# Altium schematic sheet 03_MCU-0220_S32K324.SchDoc
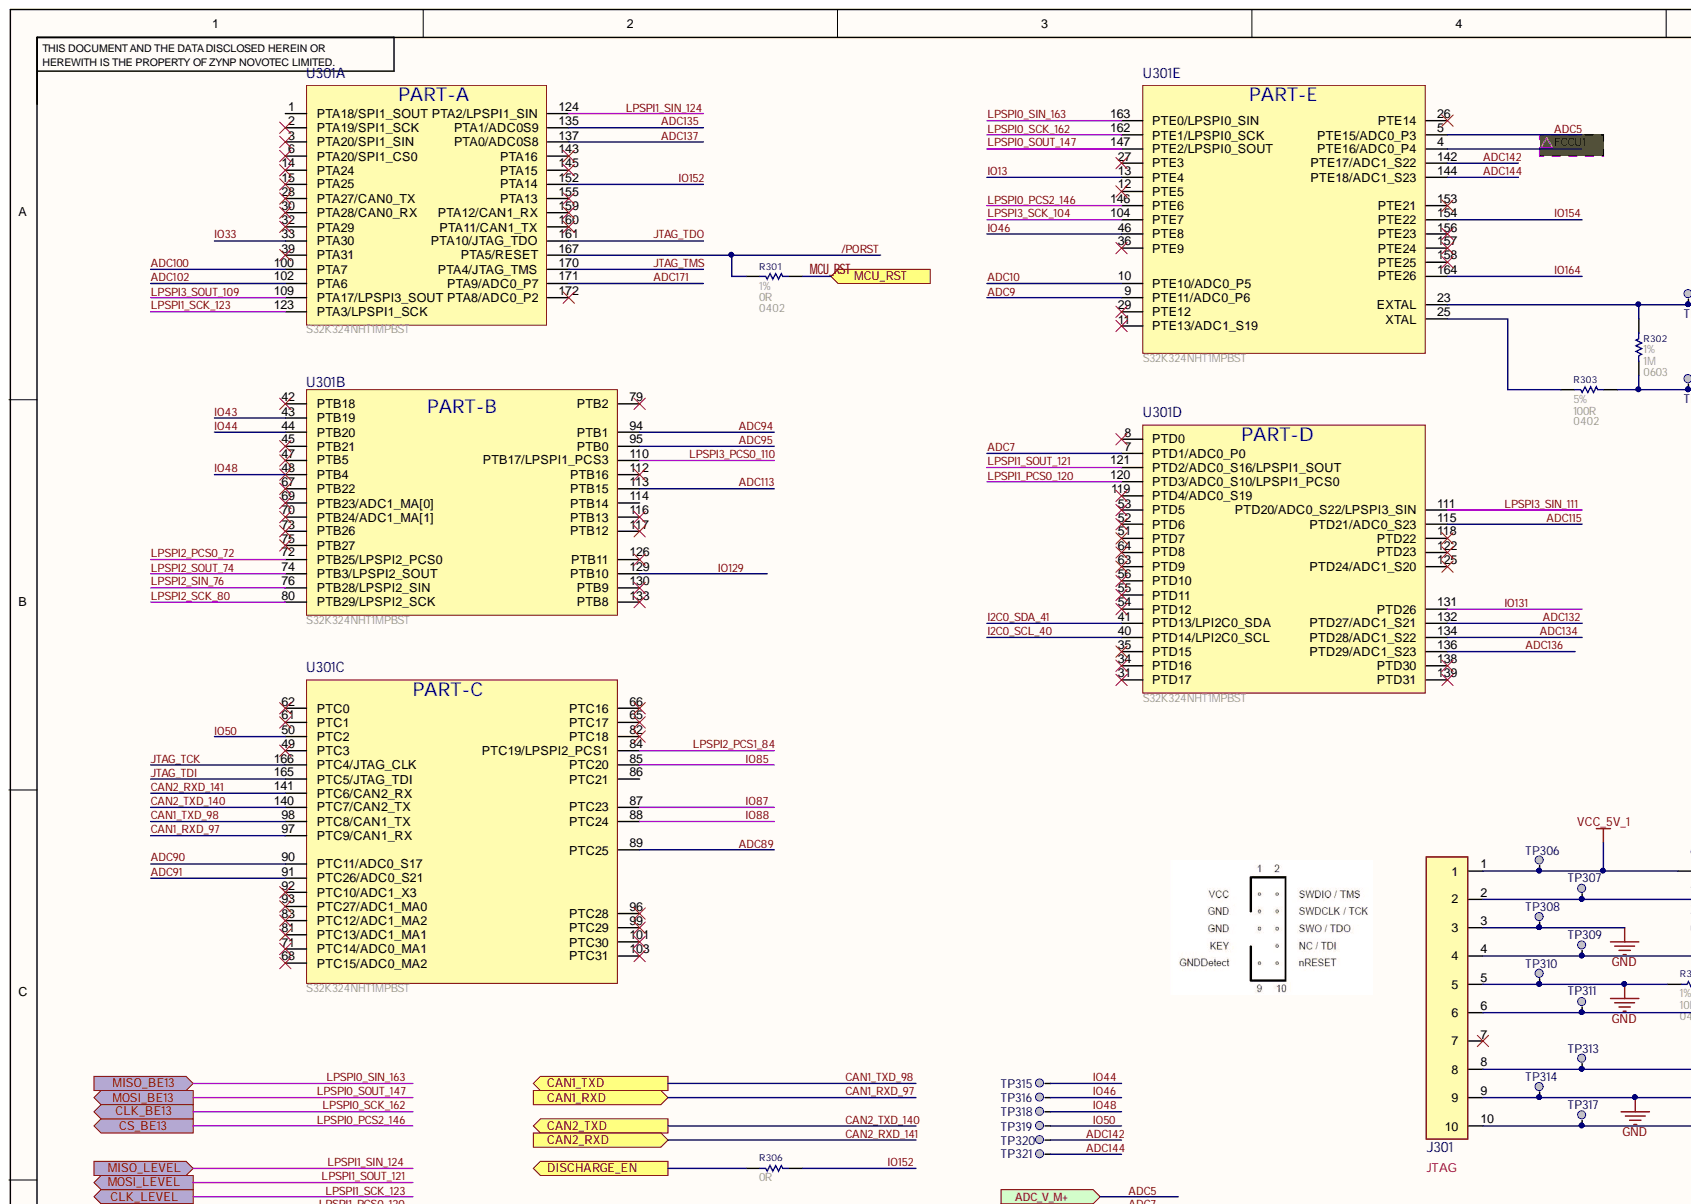
[diagram p0: 03_MCU-0220_S32K324.SchDoc, top left]
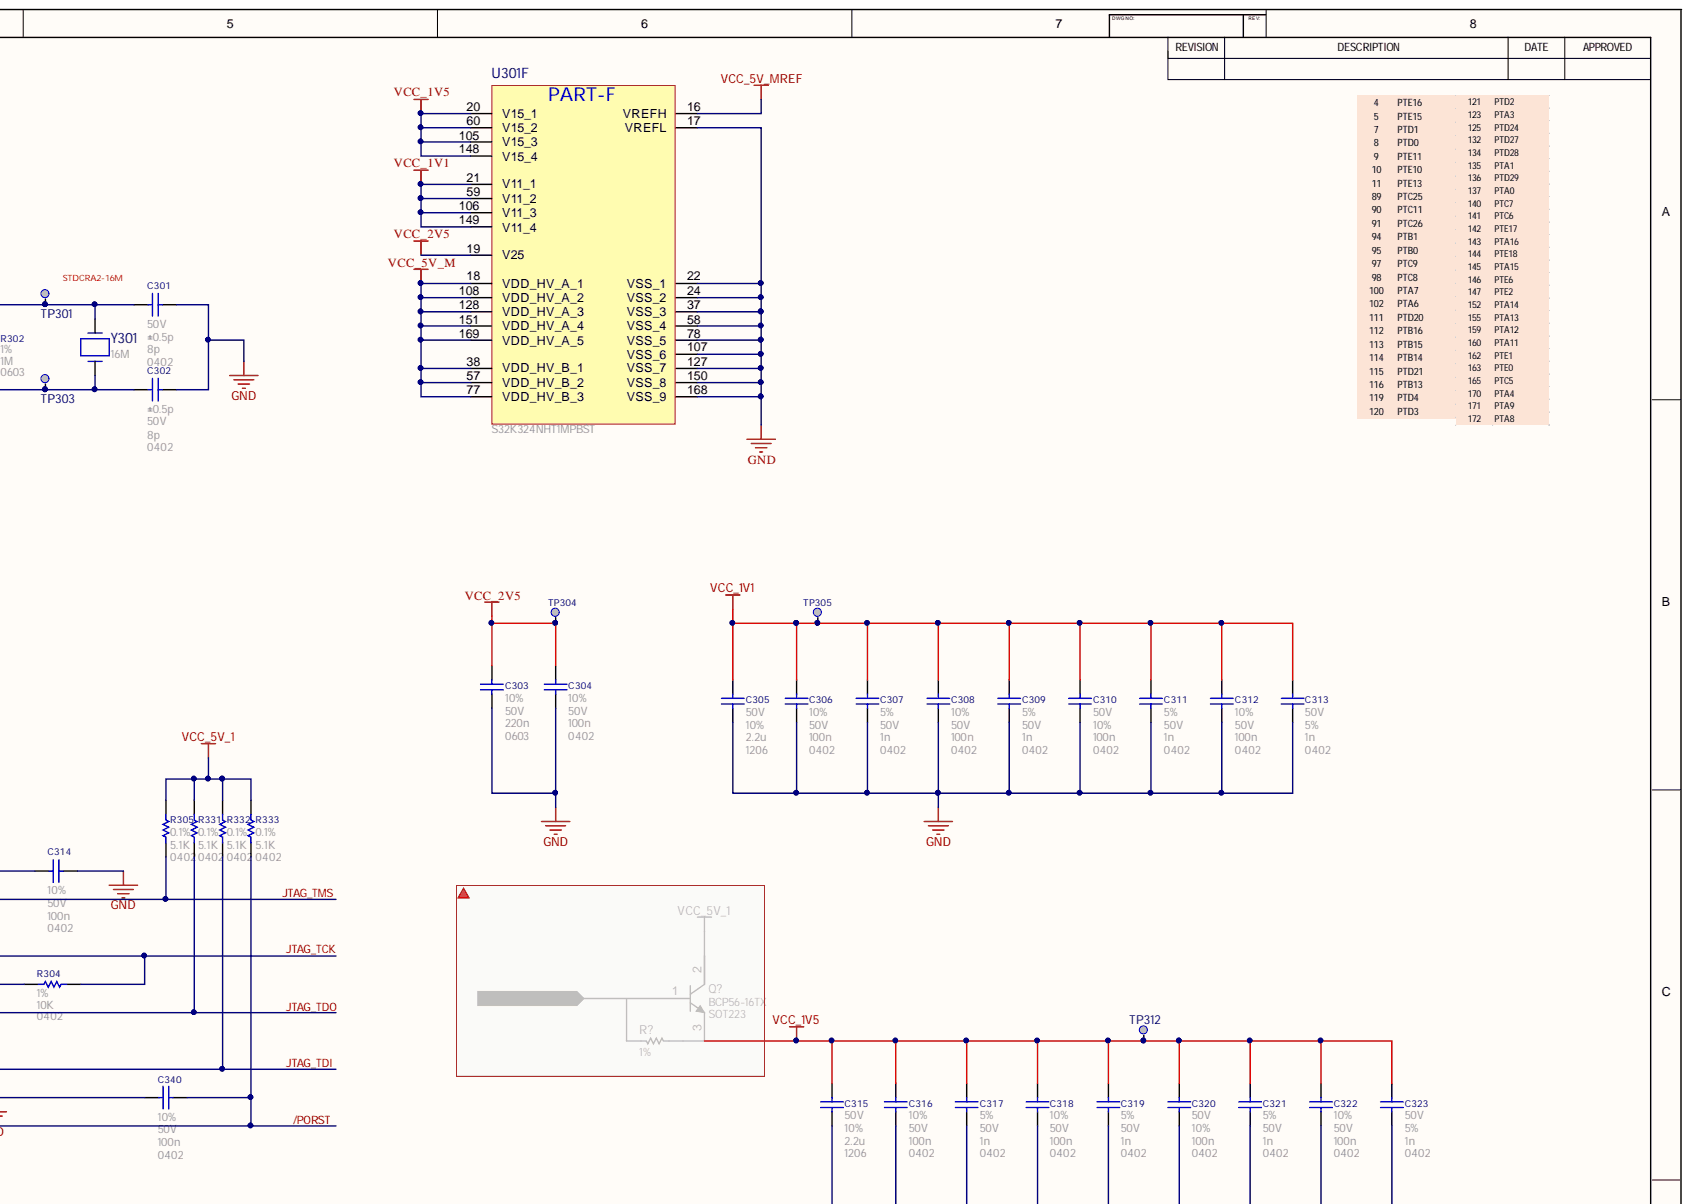
[diagram p1: 03_MCU-0220_S32K324.SchDoc, top right]
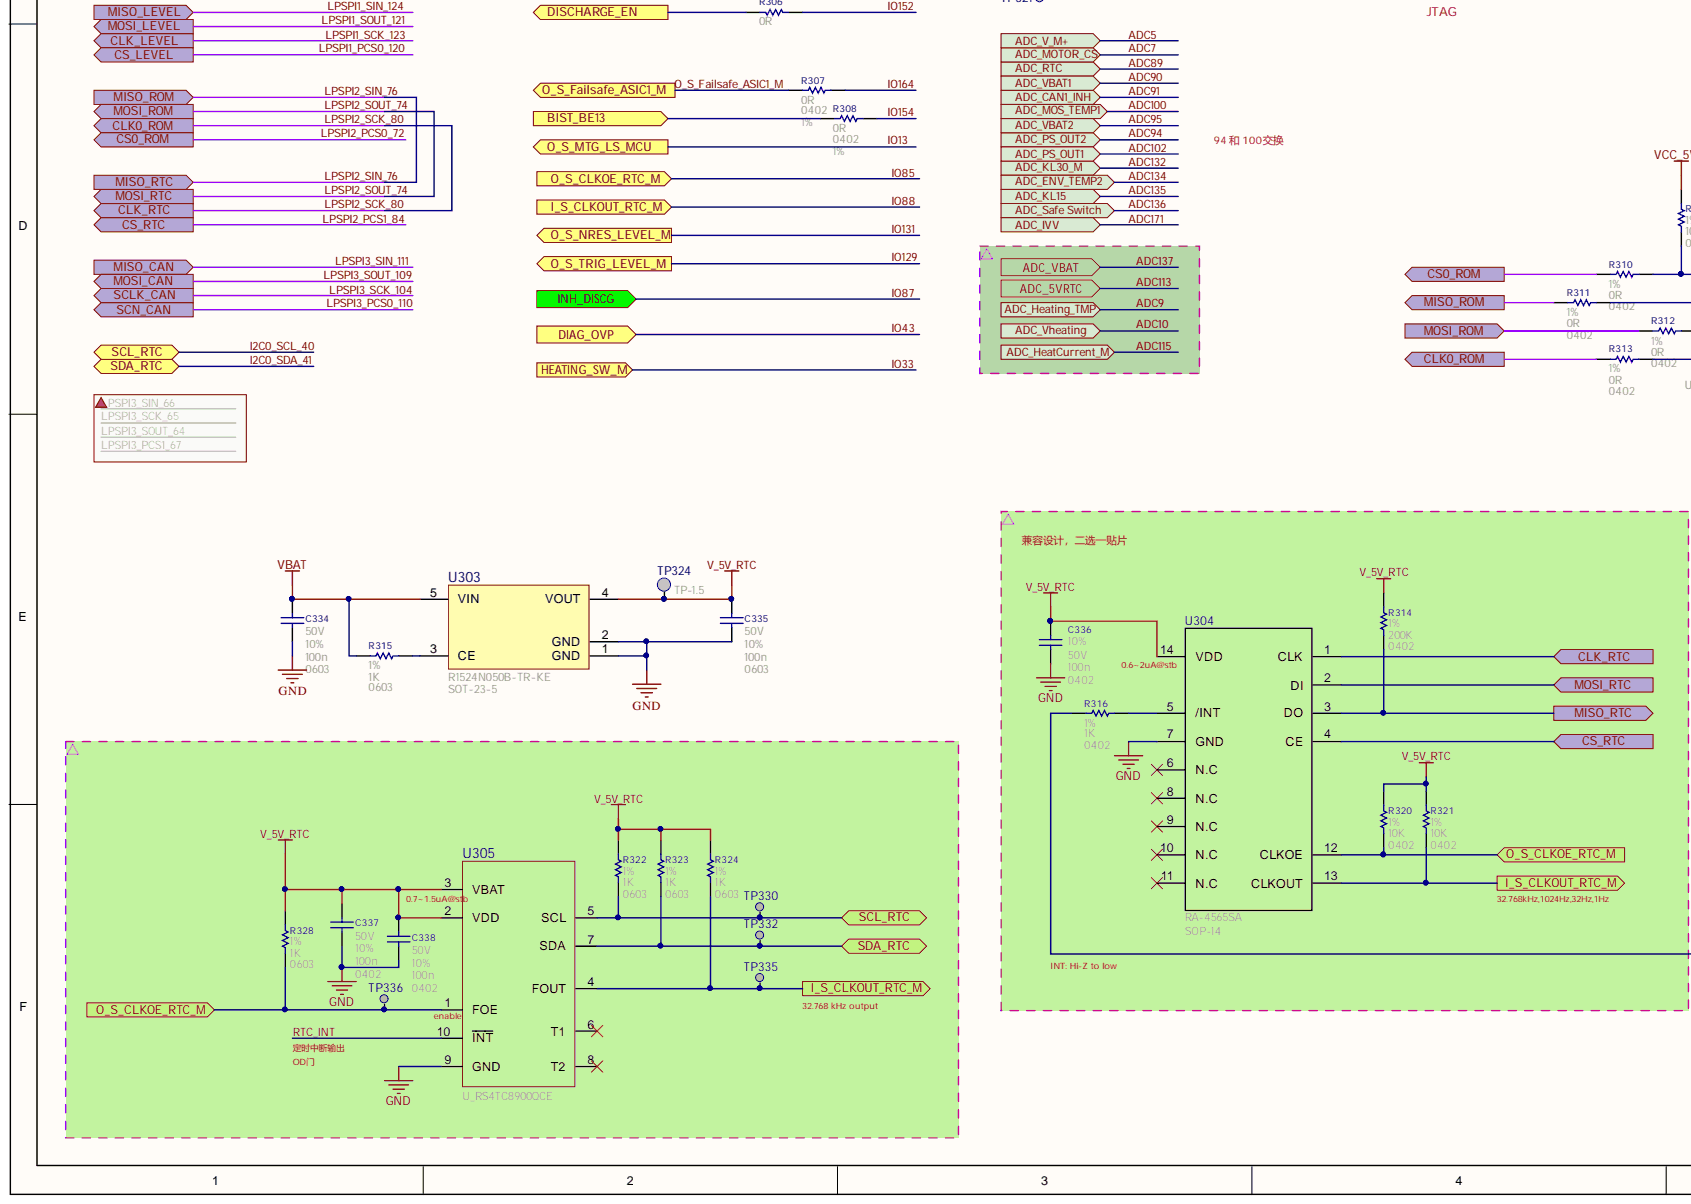
[diagram p2: 03_MCU-0220_S32K324.SchDoc, bottom left]
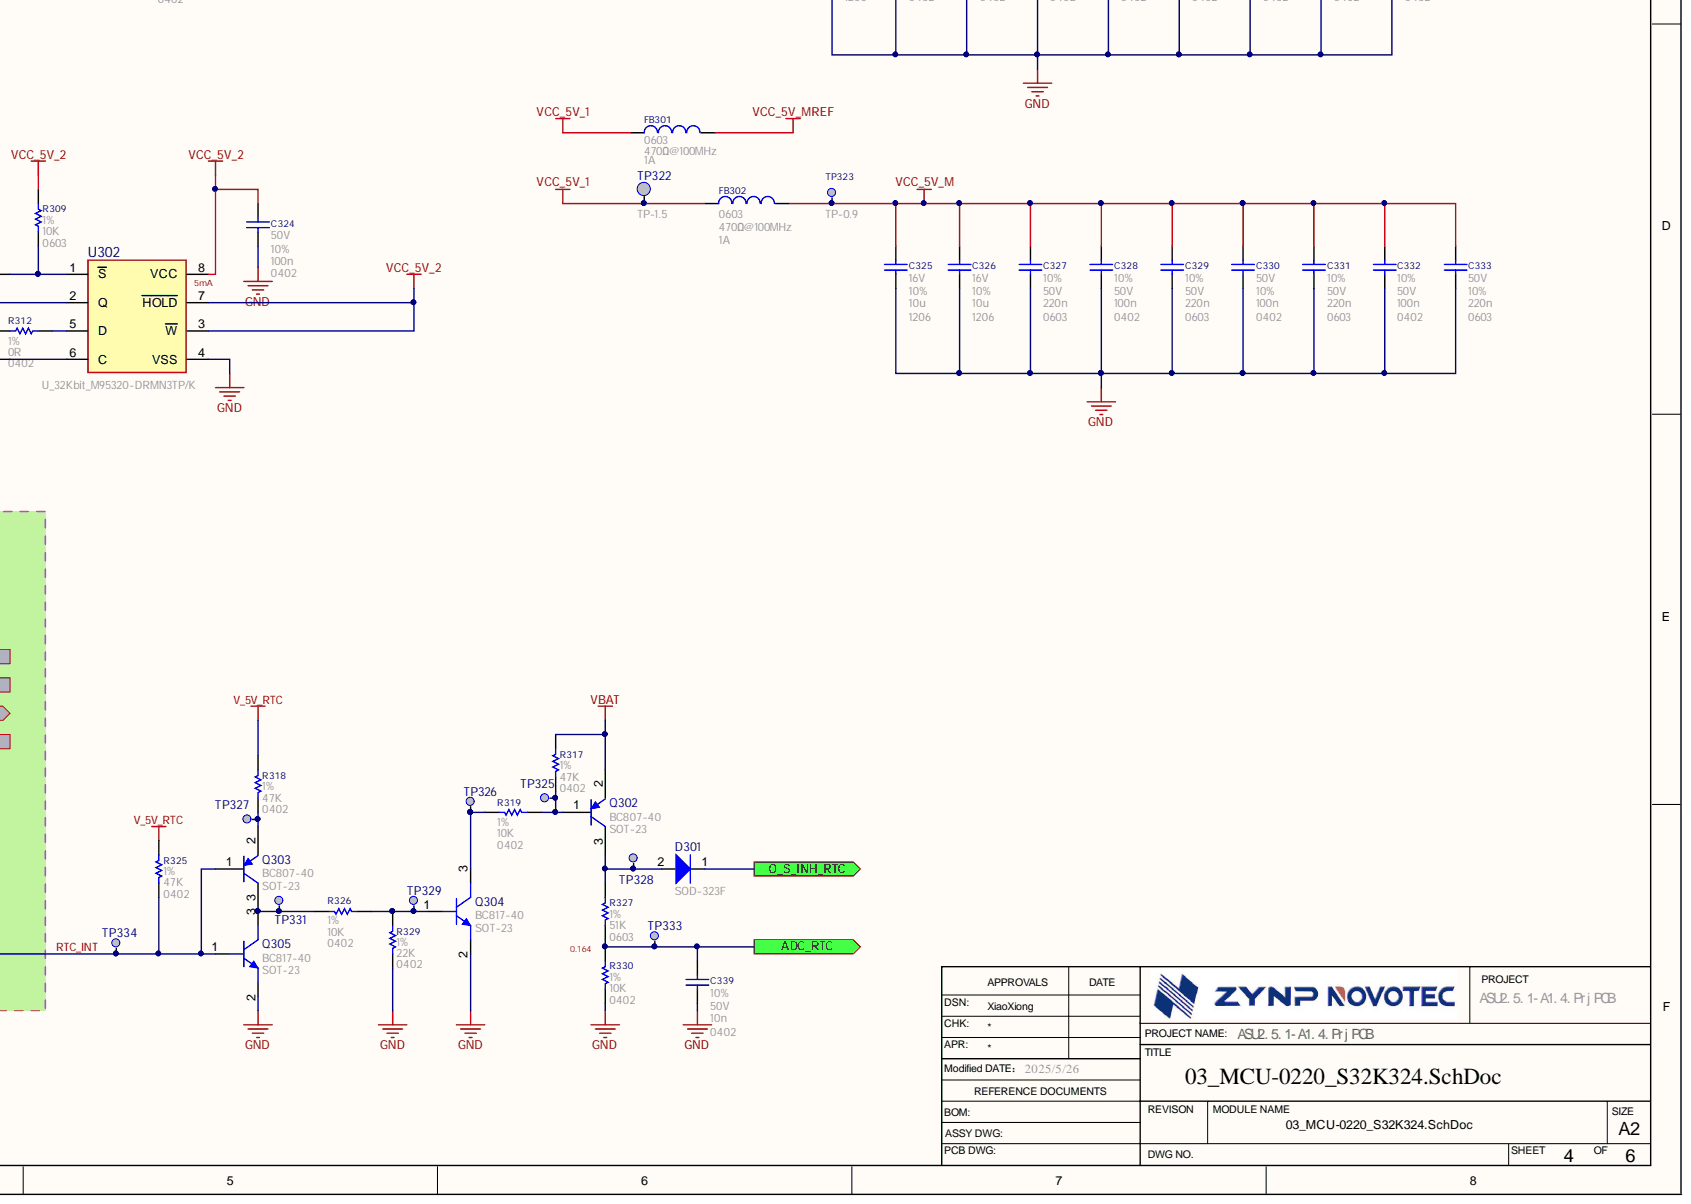
[diagram p3: 03_MCU-0220_S32K324.SchDoc, bottom right]

## Parts
C301  value 8p  Tolerance: ±0.5p  Voltage: 50V  [C0402]  (hidden comment: CAP)
C302  value 8p  Package: 0402  Tolerance: ±0.5p  Voltage: 50V  [C0402]  (hidden comment: CAP)
C303  value 220n  Package: 0603  Tolerance: 10%  Voltage: 50V  [C0603]  (hidden comment: CAP)
C304  value 100n  Package: 0402  Tolerance: 10%  Voltage: 50V  [C0402]  (hidden comment: CAP)
C305  value 2.2u  Package: 1206  Tolerance: 10%  Voltage: 50V  [C1206]  (hidden comment: CAP)
C306  value 100n  Package: 0402  Tolerance: 10%  Voltage: 50V  [C0402]  (hidden comment: CAP)
C307  value 1n  Package: 0402  Tolerance: 5%  Voltage: 50V  [C0402]  (hidden comment: CAP)
C308  value 100n  Package: 0402  Tolerance: 10%  Voltage: 50V  [C0402]  (hidden comment: CAP)
C309  value 1n  Package: 0402  Tolerance: 5%  Voltage: 50V  [C0402]  (hidden comment: CAP)
C310  value 100n  Package: 0402  Tolerance: 10%  Voltage: 50V  [C0402]  (hidden comment: CAP)
C311  value 1n  Package: 0402  Tolerance: 5%  Voltage: 50V  [C0402]  (hidden comment: CAP)
C312  value 100n  Package: 0402  Tolerance: 10%  Voltage: 50V  [C0402]  (hidden comment: CAP)
C313  value 1n  Package: 0402  Tolerance: 5%  Voltage: 50V  [C0402]  (hidden comment: CAP)
C314  value 100n  Package: 0402  Tolerance: 10%  Voltage: 50V  [C0402]  (hidden comment: CAP)
C315  value 2.2u  Package: 1206  Tolerance: 10%  Voltage: 50V  [C1206]  (hidden comment: CAP)
C316  value 100n  Package: 0402  Tolerance: 10%  Voltage: 50V  [C0402]  (hidden comment: CAP)
C317  value 1n  Package: 0402  Tolerance: 5%  Voltage: 50V  [C0402]  (hidden comment: CAP)
C318  value 100n  Package: 0402  Tolerance: 10%  Voltage: 50V  [C0402]  (hidden comment: CAP)
C319  value 1n  Package: 0402  Tolerance: 5%  Voltage: 50V  [C0402]  (hidden comment: CAP)
C320  value 100n  Package: 0402  Tolerance: 10%  Voltage: 50V  [C0402]  (hidden comment: CAP)
C321  value 1n  Package: 0402  Tolerance: 5%  Voltage: 50V  [C0402]  (hidden comment: CAP)
C322  value 100n  Package: 0402  Tolerance: 10%  Voltage: 50V  [C0402]  (hidden comment: CAP)
C323  value 1n  Package: 0402  Tolerance: 5%  Voltage: 50V  [C0402]  (hidden comment: CAP)
C324  value 100n  Package: 0402  Tolerance: 10%  Voltage: 50V  [C0402]  (hidden comment: CAP)
C325  value 10u  Package: 1206  Tolerance: 10%  Voltage: 16V  [C1206]  (hidden comment: CAP)
C326  value 10u  Package: 1206  Tolerance: 10%  Voltage: 16V  [C1206]  (hidden comment: CAP)
C327  value 220n  Package: 0603  Tolerance: 10%  Voltage: 50V  [C0603]  (hidden comment: CAP)
C328  value 100n  Package: 0402  Tolerance: 10%  Voltage: 50V  [C0402]  (hidden comment: CAP)
C329  value 220n  Package: 0603  Tolerance: 10%  Voltage: 50V  [C0603]  (hidden comment: CAP)
C330  value 100n  Package: 0402  Tolerance: 10%  Voltage: 50V  [C0402]  (hidden comment: CAP)
C331  value 220n  Package: 0603  Tolerance: 10%  Voltage: 50V  [C0603]  (hidden comment: CAP)
C332  value 100n  Package: 0402  Tolerance: 10%  Voltage: 50V  [C0402]  (hidden comment: CAP)
C333  value 220n  Package: 0603  Tolerance: 10%  Voltage: 50V  [C0603]  (hidden comment: CAP)
C334  value 100n  Package: 0603  Tolerance: 10%  Voltage: 50V  [C0603]  (hidden comment: CAP)
C335  value 100n  Package: 0603  Tolerance: 10%  Voltage: 50V  [C0603]  (hidden comment: CAP)
C336  value 100n  Package: 0402  Tolerance: 10%  Voltage: 50V  [C0402]  (hidden comment: CAP)
C337  value 100n  Tolerance: 10%  Voltage: 50V  [C0402]  (hidden comment: CAP)
C338  value 100n  Package: 0402  Tolerance: 10%  Voltage: 50V  [C0402]  (hidden comment: CAP)
C339  value 10n  Package: 0402  Tolerance: 10%  Voltage: 50V  [C0402]  (hidden comment: CAP)
C340  value 100n  Package: 0402  Tolerance: 10%  Voltage: 50V  [C0402]  (hidden comment: CAP)
D301  Diode  [SOD-323F]  (value not drawn)
FB301  value 470Ω@100MHz  Package: 0603  [L0603]  (hidden comment: Chip Ferrite Bead)
FB302  value 470Ω@100MHz  Package: 0603  Rated_current: 1A  [L0603]  (hidden comment: Chip Ferrite Bead)
J301  JTAG  [CON10-1.27X10-SMD]
Q302  value BC807-40  Part_number: BC807-40  [SOT-23]
Q303  value BC807-40  Part_number: BC807-40  [SOT-23]
Q304  value BC817-40  Part_number: BC817-40  [SOT-23]  (hidden comment: Transistor)
Q305  value BC817-40  Part_number: BC817-40  [SOT-23]  (hidden comment: Transistor)
R301  value 0R  Package: 0402  Tolerance: 1%  [R0402]  (hidden comment: Res)
R302  value 1M  Package: 0603  Tolerance: 1%  [R0603]  (hidden comment: Res)
R303  value 100R  Package: 0402  Tolerance: 5%  [R0402]  (hidden comment: Res)
R304  value 10K  Package: 0402  Tolerance: 1%  [R0402]  (hidden comment: Res)
R305  value 5.1K  Package: 0402  Tolerance: 0.1%  [R0402]  (hidden comment: RES)
R306  value 0R  [R0402]  (hidden comment: Res)
R307  value 0R  [R0402]  (hidden comment: Res)
R308  value 0R  Package: 0402  Tolerance: 1%  [R0402]  (hidden comment: Res)
R309  value 10K  Package: 0603  Tolerance: 1%  [R0603]  (hidden comment: Res)
R310  value 0R  Tolerance: 1%  [R0402]  (hidden comment: Res)
R311  value 0R  Package: 0402  Tolerance: 1%  [R0402]  (hidden comment: Res)
R312  value 0R  Tolerance: 1%  [R0402]  (hidden comment: Res)
R313  value 0R  Package: 0402  Tolerance: 1%  [R0402]  (hidden comment: Res)
R314  value 200K  Package: 0402  Tolerance: 1%  [R0402]  (hidden comment: Res)
R315  value 1K  Package: 0603  Tolerance: 1%  [R0603]  (hidden comment: Res)
R316  value 1K  Package: 0402  Tolerance: 1%  [R0402]  (hidden comment: Res)
R317  value 47K  Package: 0402  Tolerance: 1%  [R0402]  (hidden comment: Res)
R318  value 47K  Package: 0402  Tolerance: 1%  [R0402]  (hidden comment: Res)
R319  value 10K  Package: 0402  Tolerance: 1%  [R0402]  (hidden comment: Res)
R320  value 10K  Package: 0402  Tolerance: 1%  [R0402]  (hidden comment: Res)
R321  value 10K  Package: 0402  Tolerance: 1%  [R0402]  (hidden comment: Res)
R322  value 1K  Package: 0603  Tolerance: 1%  [R0603]  (hidden comment: Res)
R323  value 1K  Package: 0603  Tolerance: 1%  [R0603]  (hidden comment: Res)
R324  value 1K  Package: 0603  Tolerance: 1%  [R0603]  (hidden comment: Res)
R325  value 47K  Package: 0402  Tolerance: 1%  [R0402]  (hidden comment: Res)
R326  value 10K  Package: 0402  Tolerance: 1%  [R0402]  (hidden comment: Res)
R327  value 51K  Package: 0603  Tolerance: 1%  [R0603]  (hidden comment: Res)
R328  value 1K  Package: 0603  Tolerance: 1%  [R0603]  (hidden comment: Res)
R329  value 22K  Package: 0402  Tolerance: 1%  [R0402]  (hidden comment: Res)
R330  value 10K  Package: 0402  Tolerance: 1%  [R0402]  (hidden comment: Res)
R331  value 5.1K  Package: 0402  Tolerance: 0.1%  [R0402]  (hidden comment: RES)
R332  value 5.1K  Package: 0402  Tolerance: 0.1%  [R0402]  (hidden comment: RES)
R333  value 5.1K  Package: 0402  Tolerance: 0.1%  [R0402]  (hidden comment: RES)
TP301  TEST Point  [TP-0.9]  (value not drawn)
TP303  TEST Point  [TP-0.9]  (value not drawn)
TP304  TEST Point  [TP-0.9]  (value not drawn)
TP305  TEST Point  [TP-0.9]  (value not drawn)
TP306  TEST Point  [TP-0.9]  (value not drawn)
TP307  TEST Point  [TP-0.9]  (value not drawn)
TP308  TEST Point  [TP-0.9]  (value not drawn)
TP309  TEST Point  [TP-0.9]  (value not drawn)
TP310  TEST Point  [TP-0.9]  (value not drawn)
TP311  TEST Point  [TP-0.9]  (value not drawn)
TP312  TEST Point  [TP-0.9]  (value not drawn)
TP313  TEST Point  [TP-0.9]  (value not drawn)
TP314  TEST Point  [TP-0.9]  (value not drawn)
TP315  TEST Point  [TP-0.9]  (value not drawn)
TP316  TEST Point  [TP-0.9]  (value not drawn)
TP317  TEST Point  [TP-0.9]  (value not drawn)
TP318  TEST Point  [TP-0.9]  (value not drawn)
TP319  TEST Point  [TP-0.9]  (value not drawn)
TP320  TEST Point  [TP-0.9]  (value not drawn)
TP321  TEST Point  [TP-0.9]  (value not drawn)
TP322  Package: TP-1.5  [PAD_1.5]  (hidden comment: TEST Point)
TP323  TEST Point  [TP-0.9]  (value not drawn)
TP324  Package: TP-1.5  [PAD_1.5]  (hidden comment: TEST Point)
TP325  TEST Point  [TP-0.9]  (value not drawn)
TP326  TEST Point  [TP-0.9]  (value not drawn)
TP327  TEST Point  [TP-0.9]  (value not drawn)
TP328  TEST Point  [TP-0.9]  (value not drawn)
TP329  TEST Point  [TP-0.9]  (value not drawn)
TP330  TEST Point  [TP-0.9]  (value not drawn)
TP331  TEST Point  [TP-0.9]  (value not drawn)
TP332  TEST Point  [TP-0.9]  (value not drawn)
TP333  TEST Point  [TP-0.9]  (value not drawn)
TP334  TEST Point  [TP-0.9]  (value not drawn)
TP335  TEST Point  [TP-0.9]  (value not drawn)
TP336  TEST Point  [TP-0.9]  (value not drawn)
U301  S32K324NHT1MPBST  [NXP-172HDQFP]  (parts A,B,C,D,E,F)
U302  U_32Kbit_M95320-DRMN3TP/K  [SOP8-1_27-5_0X4_0-H1_75]
U303  value R1524N050B-TR-KE  Part_number: R1524N050B-TR-KE  [SOT-23-5]  (hidden comment: LDO)
U304  value RA-4565SA  Part_number: RA-4565SA  [SOP-14]  (hidden comment: U_RA-4565SA(SOP-14))
U305  U_RS4TC8900QCE  [x3225-10pin]
Y301  16M  [X2-3_3X2_6-H0_9]

## Ports
ADC_5VRTC, ADC_CAN1_INH, ADC_ENV_TEMP2, ADC_HeatCurrent_M, ADC_Heating_TMP, ADC_IVV, ADC_KL15, ADC_KL30_M, ADC_MOS_TEMP1, ADC_MOTOR_CS, ADC_PS_OUT1, ADC_PS_OUT2, ADC_RTC, ADC_Safe Switch, ADC_V_M+, ADC_VBAT, ADC_VBAT1, ADC_VBAT2, ADC_Vheating, BIST_BE13, CAN1_RXD, CAN1_TXD, CAN2_RXD, CAN2_TXD, CLK0_ROM, CLK_BE13, CLK_LEVEL, CLK_RTC, CS0_ROM, CS_BE13, CS_LEVEL, CS_RTC, DIAG_OVP, DISCHARGE_EN, HEATING_SW_M, I_S_CLKOUT_RTC_M, INH_DISCG, MCU_RST, MISO_BE13, MISO_CAN, MISO_LEVEL, MISO_ROM, MISO_RTC, MOSI_BE13, MOSI_CAN, MOSI_LEVEL, MOSI_ROM, MOSI_RTC, O_S_CLKOE_RTC_M, O_S_Failsafe_ASIC1_M, O_S_INH_RTC, O_S_MTG_LS_MCU, O_S_NRES_LEVEL_M, O_S_TRIG_LEVEL_M, SCL_RTC, SCLK_CAN, SCN_CAN, SDA_RTC

## Nets
GND: C301.1 C302.2 C303.1 C304.1 C305.1 C306.1 C307.1 C308.1 C309.1 C310.1 C311.1 C312.1 C313.1 C314.1 C315.1 C316.1 C317.1 C318.1 C319.1 C320.1 C321.1 C322.1 C323.1 C324.1 C325.1 C326.1 C327.1 C328.1 C329.1 C330.1 C331.1 C332.1 C333.1 C334.1 C335.1 C336.1 C337.1 C338.1 C339.1 C340.1 J301.3 J301.5 J301.9 Q304.2 Q305.2 R304.2 R329.1 R330.1 TP308.1 TP310.1 TP314.1 U301.17(VREFL) U301.22(VSS_1) U301.24(VSS_2) U301.37(VSS_3) U301.58(VSS_4) U301.78(VSS_5) U301.107(VSS_6) U301.127(VSS_7) U301.150(VSS_8) U301.168(VSS_9) U302.4(VSS) U303.1(GND) U303.2(GND) U304.7(GND) U305.9(GND)
V_5V_RTC: C335.2 C336.2 C337.2 C338.2 R314.2 R318.2 R320.1 R321.1 R322.2 R323.2 R324.2 R325.2 R328.2 TP324.1 U303.4(VOUT) U304.14(VDD) U305.2(VDD) U305.3(VBAT)
VBAT: C334.2 Q302.2 R315.2 R317.2 U303.5(VIN)
VCC_1V1: C305.2 C306.2 C307.2 C308.2 C309.2 C310.2 C311.2 C312.2 C313.2 TP305.1 U301.21(V11_1) U301.59(V11_2) U301.106(V11_3) U301.149(V11_4)
VCC_1V5: C315.2 C316.2 C317.2 C318.2 C319.2 C320.2 C321.2 C322.2 C323.2 TP312.1 U301.20(V15_1) U301.60(V15_2) U301.105(V15_3) U301.148(V15_4)
VCC_2V5: C303.2 C304.2 TP304.1 U301.19(V25)
VCC_5V_1: C314.2 FB301.1 FB302.2 J301.1 R305.1 R331.1 R332.1 R333.1 TP306.1 TP322.1
VCC_5V_2: C324.2 R309.2 U302.3(W) U302.7(HOLD) U302.8(VCC)
VCC_5V_M: C325.2 C326.2 C327.2 C328.2 C329.2 C330.2 C331.2 C332.2 C333.2 FB302.1 TP323.1 U301.18(VDD_HV_A_1) U301.38(VDD_HV_B_1) U301.57(VDD_HV_B_2) U301.77(VDD_HV_B_3) U301.108(VDD_HV_A_2) U301.128(VDD_HV_A_3) U301.151(VDD_HV_A_4) U301.169(VDD_HV_A_5)
VCC_5V_MREF: FB301.2 U301.16(VREFH)
/PORST: C340.2 J301.10 R301.2 R333.2 TP317.1 U301.167(PTA5/RESET)
ADC5: U301.5(PTE15/ADC0_P3) ; port ADC_V_M+
ADC7: U301.7(PTD1/ADC0_P0) ; port ADC_MOTOR_CS
ADC9: U301.9(PTE11/ADC0_P6) ; port ADC_Heating_TMP
ADC10: U301.10(PTE10/ADC0_P5) ; port ADC_Vheating
ADC89: C339.2 R327.1 R330.2 TP333.1 U301.89(PTC25) ; port ADC_RTC
ADC90: U301.90(PTC11/ADC0_S17) ; port ADC_VBAT1
ADC91: U301.91(PTC26/ADC0_S21) ; port ADC_CAN1_INH
ADC94: U301.94(PTB1) ; port ADC_PS_OUT2
ADC95: U301.95(PTB0) ; port ADC_VBAT2
ADC100: U301.100(PTA7) ; port ADC_MOS_TEMP1
ADC102: U301.102(PTA6) ; port ADC_PS_OUT1
ADC113: U301.113(PTB15) ; port ADC_5VRTC
ADC115: U301.115(PTD21/ADC0_S23) ; port ADC_HeatCurrent_M
ADC132: U301.132(PTD27/ADC1_S21) ; port ADC_KL30_M
ADC134: U301.134(PTD28/ADC1_S22) ; port ADC_ENV_TEMP2
ADC135: U301.135(PTA1/ADC0S9) ; port ADC_KL15
ADC136: U301.136(PTD29/ADC1_S23) ; port ADC_Safe Switch
ADC137: U301.137(PTA0/ADC0S8) ; port ADC_VBAT
ADC142: TP320.1 U301.142(PTE17/ADC1_S22)
ADC144: TP321.1 U301.144(PTE18/ADC1_S23)
ADC171: U301.171(PTA9/ADC0_P7) ; port ADC_IVV
BIST_BE13: R308.1 ; port BIST_BE13
CAN1_RXD_97: U301.97(PTC9/CAN1_RX) ; port CAN1_RXD
CAN1_TXD_98: U301.98(PTC8/CAN1_TX) ; port CAN1_TXD
CAN2_RXD_141: U301.141(PTC6/CAN2_RX) ; port CAN2_RXD
CAN2_TXD_140: U301.140(PTC7/CAN2_TX) ; port CAN2_TXD
DISCHARGE_EN: R306.2 ; port DISCHARGE_EN
FCCU1: U301.4(PTE16/ADC0_P4)
I2C0_SCL_40: R322.1 TP330.1 U301.40(PTD14/LPI2C0_SCL) U305.5(SCL) ; port SCL_RTC
I2C0_SDA_41: R323.1 TP332.1 U301.41(PTD13/LPI2C0_SDA) U305.7(SDA) ; port SDA_RTC
IO13: U301.13(PTE4) ; port O_S_MTG_LS_MCU
IO33: U301.33(PTA30) ; port HEATING_SW_M
IO43: U301.43(PTB19) ; port DIAG_OVP
IO44: TP315.1 U301.44(PTB20)
IO46: TP316.1 U301.46(PTE8)
IO48: TP318.1 U301.48(PTB4)
IO50: TP319.1 U301.50(PTC2)
IO85: R320.2 R328.1 TP336.1 U301.85(PTC20) U304.12(CLKOE) U305.1(FOE) ; port O_S_CLKOE_RTC_M
IO87: U301.87(PTC23) ; port INH_DISCG
IO88: R321.2 R324.1 TP335.1 U301.88(PTC24) U304.13(CLKOUT) U305.4(FOUT) ; port I_S_CLKOUT_RTC_M
IO129: U301.129(PTB10) ; port O_S_TRIG_LEVEL_M
IO131: U301.131(PTD26) ; port O_S_NRES_LEVEL_M
IO152: R306.1 U301.152(PTA14)
IO154: R308.2 U301.154(PTE22)
IO164: R307.2 U301.164(PTE26)
JTAG_TCK: J301.4 R304.1 TP309.1 U301.166(PTC4/JTAG_CLK)
JTAG_TDI: J301.8 R332.2 TP313.1 U301.165(PTC5/JTAG_TDI)
JTAG_TDO: J301.6 R331.2 TP311.1 U301.161(PTA10/JTAG_TDO)
JTAG_TMS: J301.2 R305.2 TP307.1 U301.170(PTA4/JTAG_TMS)
LPSPI0_PCS2_146: U301.146(PTE6) ; port CS_BE13
LPSPI0_SCK_162: U301.162(PTE1/LPSPI0_SCK) ; port CLK_BE13
LPSPI0_SIN_163: U301.163(PTE0/LPSPI0_SIN) ; port MISO_BE13
LPSPI0_SOUT_147: U301.147(PTE2/LPSPI0_SOUT) ; port MOSI_BE13
LPSPI1_PCS0_120: U301.120(PTD3/ADC0_S10/LPSPI1_PCS0) ; port CS_LEVEL
LPSPI1_SCK_123: U301.123(PTA3/LPSPI1_SCK) ; port CLK_LEVEL
LPSPI1_SIN_124: U301.124(PTA2/LPSPI1_SIN) ; port MISO_LEVEL
LPSPI1_SOUT_121: U301.121(PTD2/ADC0_S16/LPSPI1_SOUT) ; port MOSI_LEVEL
LPSPI2_PCS0_72: R310.1 U301.72(PTB25/LPSPI2_PCS0) ; port CS0_ROM
LPSPI2_PCS1_84: U301.84(PTC19/LPSPI2_PCS1) U304.4(CE) ; port CS_RTC
LPSPI2_SCK_80: R313.1 U301.80(PTB29/LPSPI2_SCK) U304.1(CLK) ; port CLK0_ROM, port CLK_RTC
LPSPI2_SIN_76: R311.1 R314.1 U301.76(PTB28/LPSPI2_SIN) U304.3(DO) ; port MISO_ROM, port MISO_RTC
LPSPI2_SOUT_74: R312.1 U301.74(PTB3/LPSPI2_SOUT) U304.2(DI) ; port MOSI_ROM, port MOSI_RTC
LPSPI3_PCS0_110: U301.110(PTB17/LPSPI1_PCS3) ; port SCN_CAN
LPSPI3_SCK_104: U301.104(PTE7) ; port SCLK_CAN
LPSPI3_SIN_111: U301.111(PTD20/ADC0_S22/LPSPI3_SIN) ; port MISO_CAN
LPSPI3_SOUT_109: U301.109(PTA17/LPSPI3_SOUT) ; port MOSI_CAN
MCU_RST: R301.1 ; port MCU_RST
O_S_Failsafe_ASIC1_M: R307.1 ; port O_S_Failsafe_ASIC1_M
O_S_INH_RTC: D301.1 ; port O_S_INH_RTC
RTC_INT: Q303.1 Q305.1 R316.1 R325.1 TP334.1 U305.10(INT)
NetC301_2: C301.2 R302.1 TP301.1 U301.23(EXTAL) Y301.2
NetC302_1: C302.1 R302.2 R303.2 TP303.1 Y301.1
NetD301_2: D301.2 Q302.3 R327.2 TP328.1
NetQ302_1: Q302.1 R317.1 R319.2 TP325.1
NetQ303_2: Q303.2 R318.1 TP327.1
NetQ303_3: Q303.3 Q305.3 R326.1 TP331.1
NetQ304_1: Q304.1 R326.2 R329.2 TP329.1
NetQ304_3: Q304.3 R319.1 TP326.1
NetR303_1: R303.1 U301.25(XTAL)
NetR309_1: R309.1 R310.2 U302.1(S)
NetR311_2: R311.2 U302.2(Q)
NetR312_2: R312.2 U302.5(D)
NetR313_2: R313.2 U302.6(C)
NetR315_1: R315.1 U303.3(CE)
NetR316_2: R316.2 U304.5(/INT)

Not connected: J301 7; U301 1(PTA18/SPI1_SOUT) 2(PTA19/SPI1_SCK) 3(PTA20/SPI1_SIN) 6(PTA20/SPI1_CS0) 8(PTD0) 11(PTE13/ADC1_S19) 12(PTE5) 14(PTA24) 15(PTA25) 26(PTE14) 27(PTE3) 28(PTA27/CAN0_TX) 29(PTE12) 30(PTA28/CAN0_RX) 31(PTD17) 32(PTA29) 34(PTD16) 35(PTD15) 36(PTE9) 39(PTA31) 42(PTB18) 45(PTB21) 47(PTB5) 49(PTC3) 51(PTD7) 52(PTD6) 53(PTD5) 54(PTD12) 55(PTD11) 56(PTD10) 61(PTC1) 62(PTC0) 63(PTD9) 64(PTD8) 65(PTC17) 66(PTC16) 67(PTB22) 68(PTC15/ADC0_MA2) 69(PTB23/ADC1_MA[0]) 70(PTB24/ADC1_MA[1]) 71(PTC14/ADC0_MA1) 73(PTB26) 75(PTB27) 79(PTB2) 81(PTC13/ADC1_MA1) 82(PTC18) 83(PTC12/ADC1_MA2) 86(PTC21) 92(PTC10/ADC1_X3) 93(PTC27/ADC1_MA0) 96(PTC28) 99(PTC29) 101(PTC30) 103(PTC31) 112(PTB16) 114(PTB14) 116(PTB13) 117(PTB12) 118(PTD22) 119(PTD4/ADC0_S19) 122(PTD23) 125(PTD24/ADC1_S20) 126(PTB11) 130(PTB9) 133(PTB8) 138(PTD30) 139(PTD31) 143(PTA16) 145(PTA15) 153(PTE21) 155(PTA13) 156(PTE23) 157(PTE24) 158(PTE25) 159(PTA12/CAN1_RX) 160(PTA11/CAN1_TX) 172(PTA8/ADC0_P2); U304 6(N.C) 8(N.C) 9(N.C) 10(N.C) 11(N.C); U305 6(T1) 8(T2)

## Library data of the parts
C301 = C_8p_50V_±0.5p_GCM1555C1H8R0DA16D: 112000089; Manufacturer: Murata; Location: Japan; Regulation: GCM1555C1H8R0DA16D、Murata、8p、±0.5p、50V、C0G、-55℃~125℃、0402; SAP_number: 10052208; Specification: RoHS AEC-Q200; Temperature_char: C0G; Type: 陶瓷电容; Value: 8p; Component Kind: Standard; Library Name: RLC.SchLib; Package: 0402; Part_number: GCM1555C1H8R0DA16D; TC_number: 112000089; Temperature: -55℃~125℃
C302 = C_8p_50V_±0.5p_GCM1555C1H8R0DA16D: 112000089; Manufacturer: Murata; Location: Japan; Regulation: GCM1555C1H8R0DA16D、Murata、8p、±0.5p、50V、C0G、-55℃~125℃、0402; SAP_number: 10052208; Specification: RoHS AEC-Q200; Temperature_char: C0G; Type: 陶瓷电容; Component Kind: Standard; Library Name: RLC.SchLib; Part_number: GCM1555C1H8R0DA16D; TC_number: 112000089; Temperature: -55℃~125℃
C303 C327 C329 C331 C333 = C_220n_50V_10%_GCM188R71H224KA64D: 112000164; Manufacturer: Murata; Location: Japan; Regulation: GCM188R71H224KA64D、Murata、220n、10%、50V、X7R、-55℃~125℃、0603; SAP_number: 10056385; Specification: RoHS AEC-Q200; Temperature_char: X7R; Type: 陶瓷电容; Component Kind: Standard; Library Name: RLC.SchLib; Part_number: GCM188R71H224KA64D; TC_number: 112000164; Temperature: -55℃~125℃
C304 C306 C308 C310 C312 C314 C316 C318 C320 C322 C324 C328 C330 C332 C336-C338 C340 = C_100n_50V_10%_GCM155R71H104KE02D: 112000115; Manufacturer: Murata; Location: Japan; Regulation: GCM155R71H104KE02D、Murata、100n、10%、50V、X7R、-55℃~125℃、0402; SAP_number: 10052237; Specification: RoHS AEC-Q200; Temperature_char: X7R; Type: 陶瓷电容; Component Kind: Standard; Library Name: RLC.SchLib; Part_number: GCM155R71H104KE02D; TC_number: 112000115; Temperature: -55℃~125℃
  C337: Package: 0402
C305 C315 = C_2.2u_50V_10%_GCM31CR71H225KA55L: 112000109; Manufacturer: Murata; Location: Japan; Regulation: GCM31CR71H225KA55L、Murata、2.2u、10%、50V、X7R、-55℃~125℃、1206; SAP_number: 10056844; Specification: RoHS AEC-Q200; Temperature_char: X7R; Type: 陶瓷电容; Component Kind: Standard; Library Name: RLC.SchLib; Part_number: GCM31CR71H225KA55L; TC_number: 112000109; Temperature: -55℃~125℃
C307 C309 C311 C313 C317 C319 C321 C323 = C_1n_50V_5%_GCM1555G1H102JA16D: 112000067; Manufacturer: Murata; Location: Japan; Regulation: GCM1555G1H102JA16D、Murata、1n、5%、50V、X8G、-55℃~150℃、0402; SAP_number: 10056779; Specification: RoHS AEC-Q200; Temperature_char: X8G; Type: 陶瓷电容; Component Kind: Standard; Library Name: RLC.SchLib; Part_number: GCM1555G1H102JA16D; TC_number: 112000067; Temperature: -55℃~150℃
C325 C326 = C_10u_16V_10%_GCM31CR71C106KA64L: 112000107; Manufacturer: Murata; Location: Japan; Regulation: GCM31CR71C106KA64L、Murata、10u、10%、16V、X7R、-55℃~125℃、1206; SAP_number: 10055348; Specification: RoHS AEC-Q200; Temperature_char: X7R; Type: 陶瓷电容; Component Kind: Standard; Library Name: RLC.SchLib; Part_number: GCM31CR71C106KA64L; TC_number: 112000107; Temperature: -55℃~125℃
C334 C335 = C_100n_50V_10%_GCM188R71H104KA57D: 112000101; Manufacturer: Murata; Location: Japan; Regulation: GCM188R71H104KA57D、Murata、100n、10%、50V、X7R、-55℃~125℃、0603; SAP_number: 10055330; Specification: RoHS AEC-Q200; Temperature_char: X7R; Type: 陶瓷电容; Component Kind: Standard; Library Name: RLC.SchLib; Part_number: GCM188R71H104KA57D; TC_number: 112000101; Temperature: -55℃~125℃
C339 = C_10n_50V_10%_GCM155R71H103KA55D: 112000097; Manufacturer: Murata; Location: Japan; Regulation: GCM155R71H103KA55D、Murata、10n、10%、50V、X7R、-55℃~125℃、0402; SAP_number: 10052234; Specification: RoHS AEC-Q200; Temperature_char: X7R; Type: 陶瓷电容; Component Kind: Standard; Library Name: RLC.SchLib; Part_number: GCM155R71H103KA55D; TC_number: 112000097; Temperature: -55℃~125℃
D301 = D_300V_BAS21J,115: 113000002; Manufacturer: Nexperia; Forward Current(If): 250mA; Peak Forward Current(Ifrm): 1A; Regulation: BAS21J,115、Nexperia、250mA、300V、50ns、-65℃~150℃、SOD-323F; Reverse Voltage(Vr): 300V; SAP_number: 10046659; Specification: RoHS; type: 普通二极管; Component Kind: Standard; Library Name: Diode.SchLib; Package: SOD-323F; Part_number: BAS21J,115; TC_number: 113000002; Temperature: -65℃-150℃
FB301 = FB_470Ω@100MHz_BLM18PG471SH1D: 111000241; Manufacturer: Murata; Location: Japan; Rated_current: 1A; Regulation: BLM18PG471SH1D、Murata、磁珠、470@100MHz、25%、1A、-55℃~125℃、0603; SAP_number: 10052683; Specification: RoHS AEC-Q200; Tolerance: 25%; Type: 磁珠; Component Kind: Standard; Library Name: RLC.SchLib; Part_number: BLM18PG471SH1D; TC_number: 111000241; Temperature: -55℃~125℃
FB302 = FB_470Ω@100MHz_BLM18PG471SH1D: 111000241; Manufacturer: Murata; Location: Japan; Regulation: BLM18PG471SH1D、Murata、磁珠、470@100MHz、25%、1A、-55℃~125℃、0603; SAP_number: 10052683; Specification: RoHS AEC-Q200; Tolerance: 25%; Type: 磁珠; Component Kind: Standard; Library Name: RLC.SchLib; Part_number: BLM18PG471SH1D; TC_number: 111000241; Temperature: -55℃~125℃
Q302 Q303 = Q_BC807-40: 114000004; Manufacturer: NXP; Channel_number: 1; Channel_type: PNP; Id or Ic: -500mA; Regulation: BC807-40、NXP、PNP、-500mA、-0.7V、-65℃~150℃、SOT-23; Type: 贴片三极管BJT; Vds or Vce: -45V; Vgs or Vbe: -5V; Component Kind: Standard; Library Name: Transistor.SchLib; Package: SOT-23; Temperature: -65℃~150℃; TP_number: 114000004
Q304 Q305 = Q_BC817-40: 114000002; Manufacturer: Nexperia; Channel_number: 1; Channel_type: NPN; Ic: 500mA; Regulation: BC817-40、NXP、NPN、500mA、0.7V、-65℃~150℃、SOT-23; SAP_number: 10013868; Specification: RoHS AEC-Q101; Type: 三极管; Vcbo: 50V; Vceo: 45V; Vebo: 5V; Component Kind: Standard; Library Name: Transistor.SchLib; Package: SOT-23; TC_number: 114000002; Temperature: -65℃~150℃
R301 R308 R311 R313 = R_0R_1/16W_1%_AC0402FR-070RL: Manufacturer: YAGEO; Location: taiwan; Power: 1/16W; Regulation: AC0402FR-070RL、YAGEO、0R、0.01、0402; Specification: RoHS AEC-Q200; Component Kind: Standard; Library Name: RLC.SchLib; Part_number: AC0402FR-070RL; Temperature: -55℃～155℃; Type: 厚膜电阻
R302 = R_1M_1/10W_1%_AC0603FR-071ML: Manufacturer: YAGEO; Location: taiwan; Power: 1/10W; Regulation: AC0603FR-071ML、YAGEO、1M、1%、0603; Specification: RoHS AEC-Q200; Component Kind: Standard; Library Name: RLC.SchLib; Part_number: AC0603FR-071ML; Temperature: -55℃～155℃; Type: 厚膜电阻
R303 = R_100R_1/16W_5%_AC0402JR-07100RL: Manufacturer: YAGEO; Location: taiwan; Power: 1/16W; Regulation: AC0402JR-07100RL、YAGEO、100R、5%、0402; Specification: RoHS AEC-Q200; Component Kind: Standard; Library Name: RLC.SchLib; Part_number: AC0402JR-07100RL; Temperature: -55℃～155℃; Type: 厚膜电阻
R304 R319-R321 R326 R330 = R_10K_1/16W_1%_AC0402FR-0710KL: Manufacturer: YAGEO; Location: taiwan; Power: 1/16W; Regulation: AC0402FR-0710KL、YAGEO、10K、1%、0402; Specification: RoHS AEC-Q200; Component Kind: Standard; Library Name: RLC.SchLib; Part_number: AC0402FR-0710KL; Temperature: -55℃～155℃; Type: 厚膜电阻
R305 R331-R333 = R_5.1K_1/16W_0.1%_AC0402FR-075K1L_0402: AC0402FR-075K1L、YAGEO、5.1k、1%、0402; Manufacturer: YAGEO; a: 111000006; Location: taiwan; Power: 1/16W; Specification: RoHS AEC-Q200; Component Kind: Standard; Library Name: RLC.SchLib; Part_number: AC0402FR-075K1L; Regulation: AC0402FR-075K1L、YAGEO、5.1k、1%、0402; Temperature: -55℃～155℃; Type: 厚膜电阻
R306 R307 = R_0R_1/16W_1%_AC0402FR-070RL: Manufacturer: YAGEO; Location: taiwan; Power: 1/16W; Regulation: AC0402FR-070RL、YAGEO、0R、0.01、0402; Specification: RoHS AEC-Q200; Tolerance: 1%; Component Kind: Standard; Library Name: RLC.SchLib; Package: 0402; Part_number: AC0402FR-070RL; Temperature: -55℃～155℃; Type: 厚膜电阻
R309 = R_10K_1/10W_1%_AC0603FR-0710KL: Manufacturer: YAGEO; Location: taiwan; Power: 1/10W; Regulation: AC0603FR-0710KL、YAGEO、10K、1%、0603; Specification: RoHS AEC-Q200; Component Kind: Standard; Library Name: RLC.SchLib; Part_number: AC0603FR-0710KL; Temperature: -55℃～155℃; Type: 厚膜电阻
R310 R312 = R_0R_1/16W_1%_AC0402FR-070RL: Manufacturer: YAGEO; Location: taiwan; Power: 1/16W; Regulation: AC0402FR-070RL、YAGEO、0R、0.01、0402; Specification: RoHS AEC-Q200; Value: 0R; Component Kind: Standard; Library Name: RLC.SchLib; Package: 0402; Part_number: AC0402FR-070RL; Temperature: -55℃～155℃; Type: 厚膜电阻
R314 = R_200K_1/16W_1%_AC0402FR-07200KL: AC0402FR-07200KL、YAGEO、200K、1%、0402; Manufacturer: YAGEO; Location: taiwan; Power: 1/16W; Specification: RoHS AEC-Q200; Component Kind: Standard; Library Name: RLC.SchLib; Part_number: AC0402FR-07200KL; Regulation: AC0402FR-07200KL、YAGEO、200K、1%、0402; Temperature: -55℃～155℃; Type: 厚膜电阻
R315 R322-R324 R328 = R_1K_1/10W_1%_AC0603FR-071KL: Manufacturer: YAGEO; Location: taiwan; Power: 1/10W; Regulation: AC0603FR-071KL、YAGEO、1K、1%、0603; Specification: RoHS AEC-Q200; Component Kind: Standard; Library Name: RLC.SchLib; Part_number: AC0603FR-071KL; Temperature: -55℃～155℃; Type: 厚膜电阻
R316 = R_1K_1/16W_1%_AC0402FR-071kL: Manufacturer: YAGEO; Location: taiwan; Power: 1/16W; Regulation: AC0402FR-071kL、YAGEO、1k、1%、0402; Specification: RoHS AEC-Q200; Component Kind: Standard; Library Name: RLC.SchLib; Part_number: AC0402FR-071kL; Temperature: -55℃～155℃; Type: 厚膜电阻
R317 R318 R325 = R_47K_1/16W_1%_AC0402FR-0747kL: AC0402FR-0747kL、YAGEO、47k、1%、0402; Manufacturer: YAGEO; Location: taiwan; Power: 1/16W; Specification: RoHS AEC-Q200; Component Kind: Standard; Library Name: RLC.SchLib; Part_number: AC0402FR-0747kL; Regulation: AC0402FR-0747kL、YAGEO、47k、1%、0402; Temperature: -55℃～155℃; Type: 厚膜电阻
R327 = R_51K_1/10W_1%_AC0603FR-0751KL: AC0603FR-0751KL、YAGEO、51K、1%、0603; Manufacturer: YAGEO; Location: taiwan; Power: 1/10W; Specification: RoHS AEC-Q200; Component Kind: Standard; Library Name: RLC.SchLib; Part_number: AC0603FR-0751KL; Regulation: AC0603FR-0751KL、YAGEO、51K、1%、0603; Temperature: -55℃～155℃; Type: 厚膜电阻
R329 = R_22K_1/16W_1%_AC0402FR-0722KL: Manufacturer: YAGEO; Location: taiwan; Power: 1/16W; Regulation: AC0402FR-0722KL、YAGEO、22K、1%、0402; Specification: RoHS AEC-Q200; Component Kind: Standard; Library Name: RLC.SchLib; Part_number: AC0402FR-0722KL; Temperature: -55℃～155℃; Type: 厚膜电阻
TP301 TP303-TP321 TP323 TP325-TP336 = TEST_PIN_0.9: TP; Component Kind: Standard; Library Name: Header.SchLib; Package: TP-0.9; Type: test pin; Value: TEST
TP322 TP324 = TEST_PIN_1.5: TP; Component Kind: Standard; Library Name: Header.SchLib; Value: TEST
U301 = U_S32K322NHT0MPBST(172HDQFP): Manufacturer: NXP; Pin counter: 172; Regulation: S32K322NHT0MPBST、NXP、MCU、2M、-40℃~125℃、172HDQFP; Component Kind: Standard; Description: S32K324NHT1MPBST; Library Name: IC.SchLib; Package: 172HDQFP; Part_number: S32K324NHT1MPBST; Temperature: -40℃~125℃
U302 = U_32Kbit_M95320-DRMN3TP/K: Manufacturer: ST; regulation: M95320-DRMN3TP/K、ST、EEPROM、32Kbit、SPI、-40℃~125℃、SO8N; Component Kind: Standard; Library Name: IC.SchLib; package: SO8N; part number: M95320-DRMN3TP/K
U303 = U_R1524N050B-TR-KE(SOT-23-5): 117000159; Manufacturer: RICOH; Pin counter: 5; Regulation: R1524N050B-TR-KE、RICOH、LDO、200mA、-40℃~125℃、SOT23-5; SAP_number: 10055439; Component Kind: Standard; Library Name: IC.SchLib; Package: SOT-23-5; TC_number: 117000159; Temperature: -40℃~125℃; Type: LDO
U304 = U_RA-4565SA(SOP-14): 117000180; Manufacturer: EPSON TOYOCOM; Pin counter: 14; Regulation: RA-4565SA、EPSON TOYOCOM、实时时钟模块、-40℃~125℃、SOP-14; SAP_number: 10056586; Specification: RoHS AEC-Q200; Component Kind: Standard; Library Name: IC.SchLib; Package: SOP-14; TC_number: 117000180; Temperature: -40℃~125℃; Type: 实时时钟模块
U305 = U_RS4TC8900QCE: I2C bus interface-compliant real-time clock ;  3.24*2.54,Hmax1.0mm; Manufacturer: RSM; current consumption: 0.90 uA / 3 V (Typ.); interface voltage range: 2.5 V ~ 5.5 V; Regulation: I2C bus interface-compliant real-time clock , - 40℃ ~ +105 ℃, 3225 -10 pin; Stability: < ±5 ppm; time-keeping voltage range: 1.6 V~5.5 V; Component Kind: Standard; Library Name: IC.SchLib; Package: 3225 -10 pin; Part_number: RS4TC8900QCE; temperature range: - 40℃ ~ +105 ℃; type: RTC 实时时钟
Y301 = X_16MHz_NX3225GB-STDCRA2-16M: Manufacturer: NDK; regulation: NX3225GB-STDCRA2-16M、NDK、无源晶振、16M、15ppm@25℃、-40℃~150℃、8pf、2pin、3.2*2.5; Component Kind: Standard; Library Name: OSC&Relay.SchLib; package: CRYS-16M_NX3225; Part_number: NX3225GB-STDCRA2-16M; Part number: NX3225GB-STDCRA2-16M; Type: 无源晶振; Value: 16M
J301 = JTAG: Component Kind: Standard; Library Name: DBS-C1_Library.SchLib
